# Altium schematic sheet ElectronicLoad.SchDoc
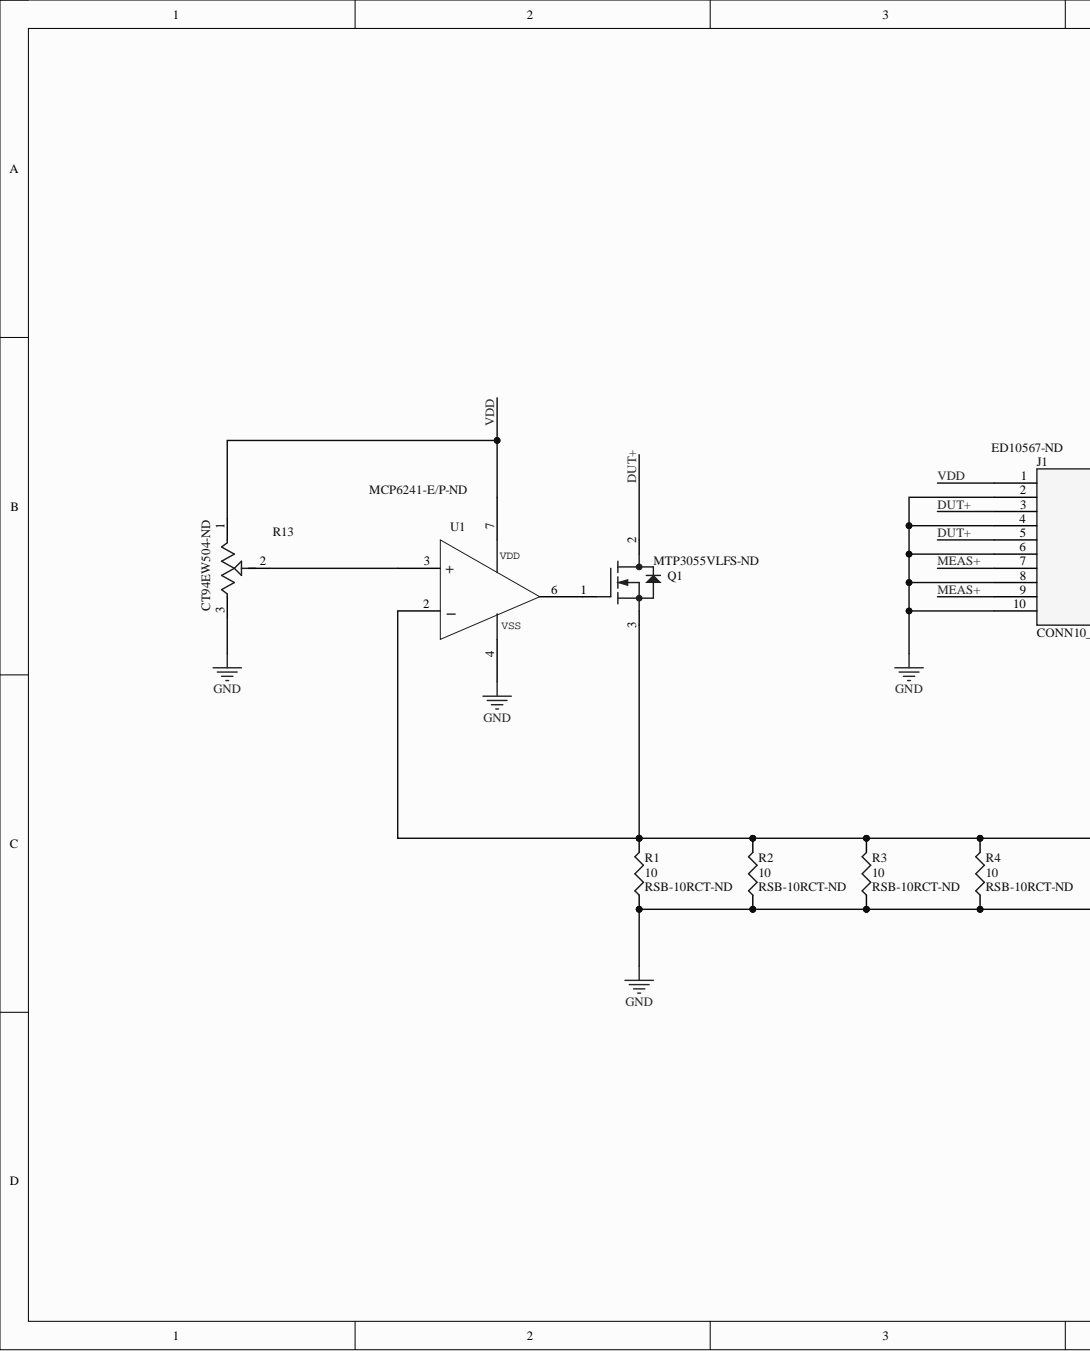
[diagram p0: ElectronicLoad.SchDoc, left]
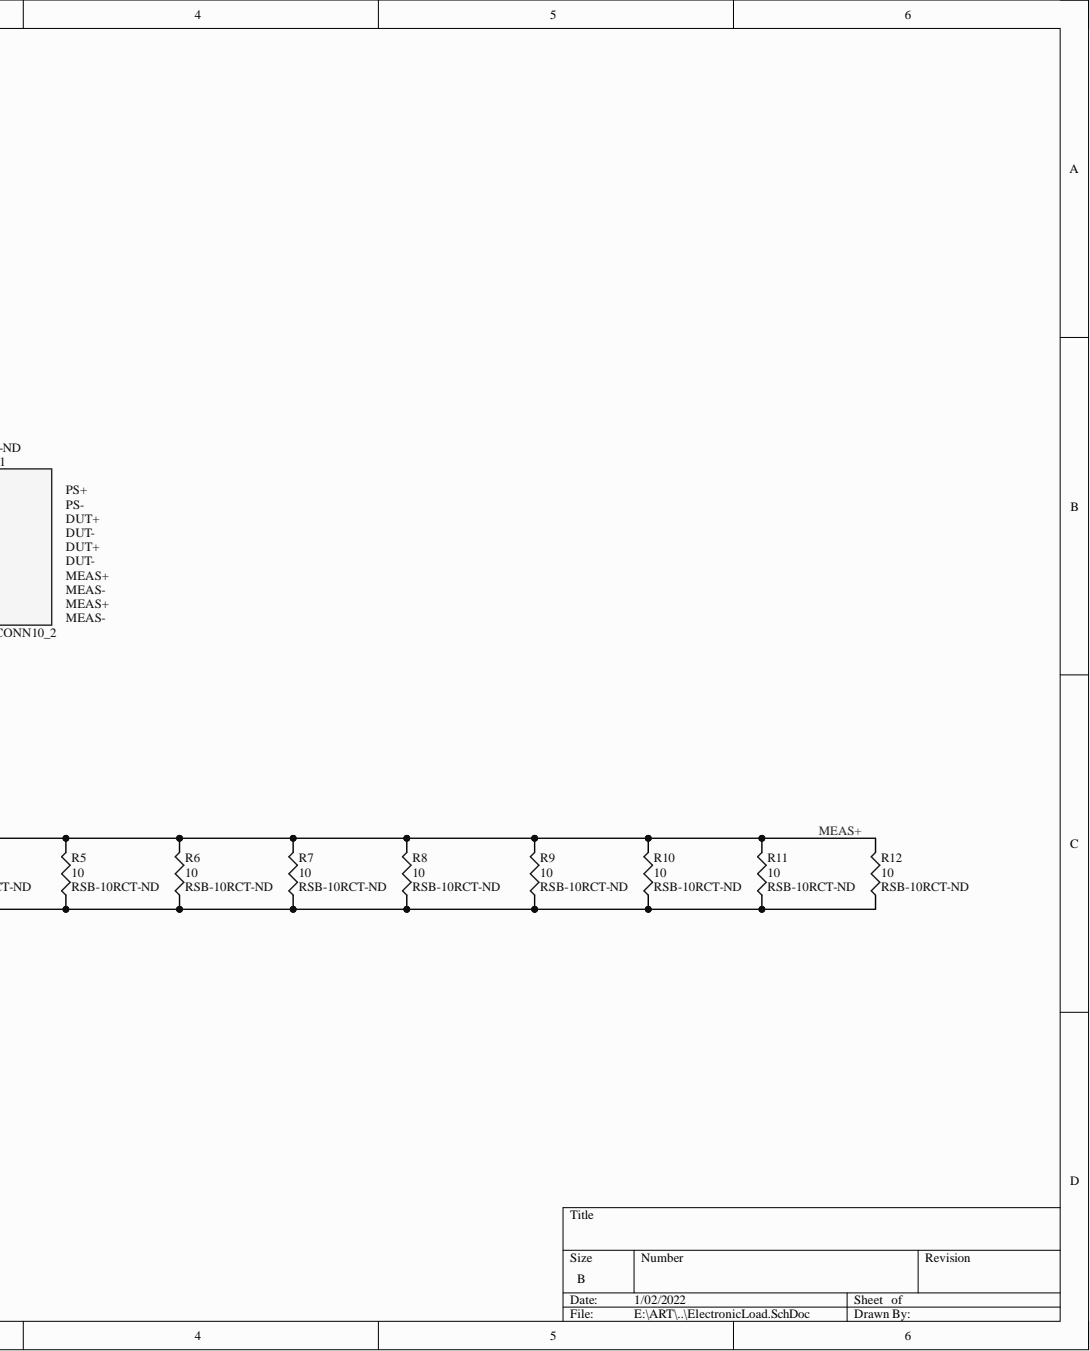
[diagram p1: ElectronicLoad.SchDoc, right]

## Parts
J1  Supplier Part Number 1: ED10567-ND  [CONN10_10X100]  (hidden comment: CONN10_2)
Q1  MTP3055VL  Supplier Part Number 1: MTP3055VLFS-ND  [TO-220-3]
R1  value 10  Supplier Part Number 1: RSB-10RCT-ND  [R_ThruHole_3W]  (hidden comment: Res3)
R2  value 10  Supplier Part Number 1: RSB-10RCT-ND  [R_ThruHole_3W]  (hidden comment: Res3)
R3  value 10  Supplier Part Number 1: RSB-10RCT-ND  [R_ThruHole_3W]  (hidden comment: Res3)
R4  value 10  Supplier Part Number 1: RSB-10RCT-ND  [R_ThruHole_3W]  (hidden comment: Res3)
R5  value 10  Supplier Part Number 1: RSB-10RCT-ND  [R_ThruHole_3W]  (hidden comment: Res3)
R6  value 10  Supplier Part Number 1: RSB-10RCT-ND  [R_ThruHole_3W]  (hidden comment: Res3)
R7  value 10  Supplier Part Number 1: RSB-10RCT-ND  [R_ThruHole_3W]  (hidden comment: Res3)
R8  value 10  Supplier Part Number 1: RSB-10RCT-ND  [R_ThruHole_3W]  (hidden comment: Res3)
R9  value 10  Supplier Part Number 1: RSB-10RCT-ND  [R_ThruHole_3W]  (hidden comment: Res3)
R10  value 10  Supplier Part Number 1: RSB-10RCT-ND  [R_ThruHole_3W]  (hidden comment: Res3)
R11  value 10  Supplier Part Number 1: RSB-10RCT-ND  [R_ThruHole_3W]  (hidden comment: Res3)
R12  value 10  Supplier Part Number 1: RSB-10RCT-ND  [R_ThruHole_3W]  (hidden comment: Res3)
R13  Supplier Part Number 1: CT94EW504-ND  [VR5]  (hidden comment: Potentiometer)
U1  MCP6241  Supplier Part Number 1: MCP6241-E/P-ND  [DIP8]

## Nets
GND: J1.2 J1.4 J1.6 J1.8 J1.10 R1.1 R2.1 R3.1 R4.1 R5.1 R6.1 R7.1 R8.1 R9.1 R10.1 R11.1 R12.1 R13.3 U1.4
DUT+: J1.3 J1.5 Q1.2
MEAS+: J1.7 J1.9 Q1.3 R1.2 R2.2 R3.2 R4.2 R5.2 R6.2 R7.2 R8.2 R9.2 R10.2 R11.2 R12.2 U1.2(-)
VDD: J1.1 R13.1 U1.7
NetQ1_1: Q1.1 U1.6
NetR13_2: R13.2 U1.3(+)

## Library data of the parts
J1 = CONN10_2: Conn Terminal Block 10 POS 2.54mm Solder ST Thru-Hole 6A; Manufacturer: On-Shore Technology; Manufacturer Part Number: OSTVN10A150; Number of Levels: 1; Number of Positions Per Level: 10; Pitch: 0.1inch; Plating: Brass,Steel; Voltage: 125V; Wire Gauge (Max): 30AWG; Wire Gauge (Min): 20AWG; Category: Terminal Blocks; Color: Green; Component Kind: Standard; ComponentLink1Description: https://datasheet.ciiva.com/826/ostvnxxa150-826427.pdf?src-supplier=Digi-Key; ComponentLink1URL: https://datasheet.ciiva.com/826/ostvnxxa150-826427.pdf?src-supplier=Digi-Key; Contact Plating: Brass; Current: 6A; Housing Material: Thermoplastic; Max Operating Temperature: 105°C; Min Operating Temperature: -30°C; Mount: Through Hole; Number of Pins: 10; Packaging: Bulk; Pricing 1: 1=3.10000, 10=2.34500, 25=2.17360, 50=1.95440, 100=1.90680, 250=1.66844, 500=1.52544, 1000=1.38243, 5000=1.27120 (USD); RoHS: Yes; RoHS Compliant: Yes; Series: OSTVN; Stock 1: 2455; Supplier 1: Digi-Key; Termination: Screw; Library Name: WaveMode.SchLib
Q1 = MTP3055VL: Transistor MOSFET N Channel 60 Volt 12 Amp 3 Pin TO-220-3; Manufacturer: ON Semiconductor / Fairchild; CLASS: IC; Continuous Drain Current (ID): 12A; Drain to Source Breakdown Voltage: 60V; Drain to Source Resistance: 180mR; Element Configuration: Single; Fall Time: 90ns; Gate to Source Voltage (Vgs): 15V; Input Capacitance: 570pF; Max Power Dissipation: 48W; Number of Elements: 1; Rise Time: 190ns; Threshold Voltage: 1.6V; Turn-Off Delay Time: 30ns; Turn-On Delay Time: 20ns; Case/Package: TO-220AB; Category: MOSFETs; Component Kind: Standard; ComponentLink1Description: https://datasheet.ciiva.com/22681/661064-22681921.pdf?src-supplier=Element14; ComponentLink1URL: https://datasheet.ciiva.com/22681/661064-22681921.pdf?src-supplier=Element14; ComponentLink2Description: https://datasheet.ciiva.com/4444/mtp3055vl-116369-4444450.pdf?src-supplier=Mouser; ComponentLink2URL: https://datasheet.ciiva.com/4444/mtp3055vl-116369-4444450.pdf?src-supplier=Mouser; ComponentLink3Description: https://datasheet.ciiva.com/25625/0900766b810e259a-25625324.pdf?src-supplier=RSComponents; ComponentLink3URL: https://datasheet.ciiva.com/25625/0900766b810e259a-25625324.pdf?src-supplier=RSComponents; ComponentLink4Description: https://datasheet.ciiva.com/19459/873602-19459514.pdf?src-supplier=Jameco; ComponentLink4URL: https://datasheet.ciiva.com/19459/873602-19459514.pdf?src-supplier=Jameco; ComponentLink5Description: https://datasheet.ciiva.com/956/mtp3055vl-d-956226.pdf?src-supplier=Digi-Key; ComponentLink5URL: https://datasheet.ciiva.com/956/mtp3055vl-d-956226.pdf?src-supplier=Digi-Key; ComponentLink6Description: https://datasheet.ciiva.com/26791/mtp3055vl-26791705.pdf?src-supplier=IIIC; ComponentLink6URL: https://datasheet.ciiva.com/26791/mtp3055vl-26791705.pdf?src-supplier=IIIC; ComponentLink7Description: https://datasheet.ciiva.com/8114/mtp3055vl-8114732.pdf?src-supplier=Avnet; ComponentLink7URL: https://datasheet.ciiva.com/8114/mtp3055vl-8114732.pdf?src-supplier=Avnet; ComponentLink8Description: https://datasheet.ciiva.com/16956/mtp3055vl-16956647.pdf?src-supplier=Future+Electronics; ComponentLink8URL: https://datasheet.ciiva.com/16956/mtp3055vl-16956647.pdf?src-supplier=Future+Electronics; ComponentLink9Description: https://datasheet.ciiva.com/26143/mtp3055vl-26143884.pdf?src-supplier=Avnet+Europe; ComponentLink9URL: https://datasheet.ciiva.com/26143/mtp3055vl-26143884.pdf?src-supplier=Avnet+Europe; ComponentLink10Description: https://datasheet.ciiva.com/48715/001264952-da-01-en-mosfet-n-ch-60v-1-mtp3055vl-to-220-3-fsc-48715526.pdf?src-supplier=Conrad; ComponentLink10URL: https://datasheet.ciiva.com/48715/001264952-da-01-en-mosfet-n-ch-60v-1-mtp3055vl-to-220-3-fsc-48715526.pdf?src-supplier=Conrad; Current Rating: 12A; Drain to Source Voltage (Vdss): 60V; Height: 16.51mm; Lead Free: Lead Free; Length: 10.67mm; Manufacturer Part Number: MTP3055VL; Max Operating Temperature: 175°C; Min Operating Temperature: -55°C; Mount: Through Hole; Nominal Vgs: 15V; Number of Pins: 3; On-State Resistance: 180mR; Package Quantity: 50; Packaging: Rail/Tube; Power Dissipation: 48W; Pricing 1: 1=1.55000, 10=1.38200, 100=1.07730, 500=0.88992, 1000=0.74515 (USD); Rds On Max: 180mR; REACH SVHC: No SVHC; RoHS: Yes; RoHS Compliant: Yes; Stock 1: 558; Supplier 1: Digi-Key; Voltage Rating (DC): 60V; Weight: 1.8g; Width: 4.83mm; Library Name: WaveMode.SchLib
R1-R12 = Res3: Res Wirewound 10 Ohm 1% 3W ±20ppm/°C Ceramic Hi Temp Sil AXL Thru-Hole T/R; Manufacturer: Vishay Dale; Manufacturer Part Number: RS02B10R00FE70; Lead Diameter: 0.81mm; Lead Length: 38.1mm; Resistance: 10R; Voltage Rating (DC): 5.48V; Case/Package: Ceramic; Category: Through-Hole Resistors; Component Kind: Standard; ComponentLink1Description: https://datasheet.ciiva.com/3260/rsns-3260765.pdf?src-supplier=Avnet; ComponentLink1URL: https://datasheet.ciiva.com/3260/rsns-3260765.pdf?src-supplier=Avnet; ComponentLink2Description: https://datasheet.ciiva.com/8790/rsns-8790701.pdf?src-supplier=Avnet; ComponentLink2URL: https://datasheet.ciiva.com/8790/rsns-8790701.pdf?src-supplier=Avnet; ComponentLink3Description: https://datasheet.ciiva.com/2716/rsns-2716118.pdf?src-supplier=Digi-Key; ComponentLink3URL: https://datasheet.ciiva.com/2716/rsns-2716118.pdf?src-supplier=Digi-Key; ComponentLink4Description: https://datasheet.ciiva.com/2716/rsns-2716119.pdf?src-supplier=Digi-Key; ComponentLink4URL: https://datasheet.ciiva.com/2716/rsns-2716119.pdf?src-supplier=Digi-Key; ComponentLink5Description: https://datasheet.ciiva.com/16377/rsns-16377193.pdf?src-supplier=Future+Electronics; ComponentLink5URL: https://datasheet.ciiva.com/16377/rsns-16377193.pdf?src-supplier=Future+Electronics; ComponentLink6Description: https://datasheet.ciiva.com/7734/rsns-7734117.pdf?src-supplier=Element14; ComponentLink6URL: https://datasheet.ciiva.com/7734/rsns-7734117.pdf?src-supplier=Element14; Construction: Cylindrical; Contact Plating: Tin,Matte; Diameter: 4.75mm; Features: Moisture Resistant; LatestRevisionDate: 29-May-2009; LatestRevisionNote: IPC-7351 Footprint Added.; Lead Free: Lead Free; Length: 15.8mm; Library Name: Miscellaneous Devices.IntLib; Max Operating Temperature: 250°C; Max Power Dissipation: 3W; Military Standard: Not; Min Operating Temperature: -65°C; Number of Pins: 2; Number of Terminations: 2; Package Quantity: 1; PackageDescription: Chip Resistor; PackageReference: J1-0603; Packaging: Tape and Reel; Power Rating: 3W; Pricing 1: 1=1.34000, 10=1.18400, 25=1.08880, 50=0.99320, 100=0.85950, 250=0.72580, 500=0.61120 (USD); Published: 8-Jun-2000; Radiation Hardening: No; REACH SVHC: Unknown; Resistance Tolerance: 1%; Resistor Type: Wirewound; RoHS: Yes; RoHS Compliant: Yes; Series: RS; Signal Integrity: Res; Simulation: RESISTOR; Stock 1: 3839; Supplier 1: Digi-Key; Temperature Coefficient: 20ppm/°C; Termination: Axial; Tolerance: 1%; Width: 4.75mm; Publisher: Altium Limited
R13 = Potentiometer: Res Cermet Trimmer 500K Ohm 10% 1/2W 15(Elec)/18(Mech)Turn 2.3mm (9.6 X 4.8 X 10.7mm) Pin Thru-Hole Bulk; Manufacturer: Nidec Copal; Manufacturer Part Number: CT-94EW504; Max Power Dissipation: 500mW; MF: TE Connectivity; MP: 2-1625931-5; Number of Turns: 18; Resistance: 500kR; Temperature Coefficient: 100ppm/°C; Availability: Unavailable; Category: Variable Resistors and Potentiometers; Color: Blue; Component Kind: Standard; ComponentLink1Description: https://datasheet.ciiva.com/2161/ct-94-2161937.pdf?src-supplier=Digi-Key; ComponentLink1URL: https://datasheet.ciiva.com/2161/ct-94-2161937.pdf?src-supplier=Digi-Key; Contact Plating: Tin; Height: 0.378inch; Lead Free: Lead Free; Length: 0.378inch; Max Operating Temperature: 125°C; Min Operating Temperature: -55°C; Mount: Through Hole; Package: None; Package Quantity: 50; Packaging: Bulk; Price: None; Pricing 1: 1=1.55000, 10=1.36400, 25=1.22720, 50=1.18160, 100=1.13620, 250=1.06808, 500=0.90900, 1000=0.84082, 5000=0.69084 (USD); Resistor Type: Cermet; RoHS: Yes; Series: CT94; SnapEDA_Link: https://www.snapeda.com/parts/2-1625931-5/TE%20Connectivity%20Passive%20Product/view-part/210283/?ref=snap; Stock 1: 1213; Supplier 1: Digi-Key; TE_purchase_URL: https://www.te.com/usa-en/product-2-1625931-5.html?te_bu=Cor&te_type=disp&te_campaign=seda_glo_cor-seda-global-disp-prtnr-fy19-seda-model-bom-cta_sma-317_1&elqCampaignId=32493; Termination: PC Pin; Tolerance: 10%; Weight: 920mg; Width: 0.189inch; Library Name: WaveMode.SchLib
U1 = MCP6241: IC, Op Amp, Operating Voltage, 1.8 - 5.5V, Outputs, 1, GBWP, 550kHz, PDIP-8; Manufacturer: Microchip; Manufacturer Part Number: MCP6241-E/P; Amplifier Type: GENERAL PURPOSE; Bandwidth: 650kHz; Common Mode Rejection Ratio: 60dB; Input Bias Current: 1pA; Input Offset Voltage (Vos): 5mV; Max Frequency: 1kHz; Min Input Voltage: 1.8V; Nominal Supply Current: 50uA; Number of Amplifiers: 1; Output Current: 23mA; Slew Rate: 0.3V/µs; Voltage Gain: 110dB; Case/Package: PDIP; Category: Amplifiers - Op Amps, Buffer, Instrumentation; Component Kind: Standard; ComponentLink1Description: https://datasheet.ciiva.com/1804/21882d-1804785.pdf?src-supplier=Digi-Key; ComponentLink1URL: https://datasheet.ciiva.com/1804/21882d-1804785.pdf?src-supplier=Digi-Key; ComponentLink2Description: https://datasheet.ciiva.com/11710/jp019941-11710197.pdf?src-supplier=Avnet; ComponentLink2URL: https://datasheet.ciiva.com/11710/jp019941-11710197.pdf?src-supplier=Avnet; ComponentLink3Description: https://datasheet.ciiva.com/8078/630314-8078893.pdf?src-supplier=Element14; ComponentLink3URL: https://datasheet.ciiva.com/8078/630314-8078893.pdf?src-supplier=Element14; ComponentLink4Description: https://datasheet.ciiva.com/16756/jp019941-16756015.pdf?src-supplier=Avnet+Europe; ComponentLink4URL: https://datasheet.ciiva.com/16756/jp019941-16756015.pdf?src-supplier=Avnet+Europe; ComponentLink5Description: https://datasheet.ciiva.com/14545/70048229-14545020.pdf?src-supplier=Allied+Electronics; ComponentLink5URL: https://datasheet.ciiva.com/14545/70048229-14545020.pdf?src-supplier=Allied+Electronics; Gain Bandwidth Product: 550kHz; Height: 0.195inch; Lead Free: Lead Free; Length: 10.16mm; Max Input Voltage: 5.5V; Max Operating Temperature: 125°C; Max Supply Voltage: 5.5V; Min Operating Temperature: -40°C; Min Supply Voltage: 1.8V; Mount: Through Hole; Number of Channels: 1; Number of Circuits: 1; Number of Elements: 1; Number of Pins: 8; Package Quantity: 60; Packaging: Rail/Tube; Pricing 1: 1=0.39000, 25=0.32880, 100=0.30000 (USD); Radiation Hardening: No; REACH SVHC: No SVHC; RoHS: Yes; RoHS Compliant: Yes; Stock 1: 1246; Supplier 1: Digi-Key; Supply Current: 50uA; Technology: CMOS; Unity Gain Bandwidth Product: 550kHz; Width: 0.28inch; Library Name: WaveMode.SchLib
